# Altium schematic sheet Power.SchDoc
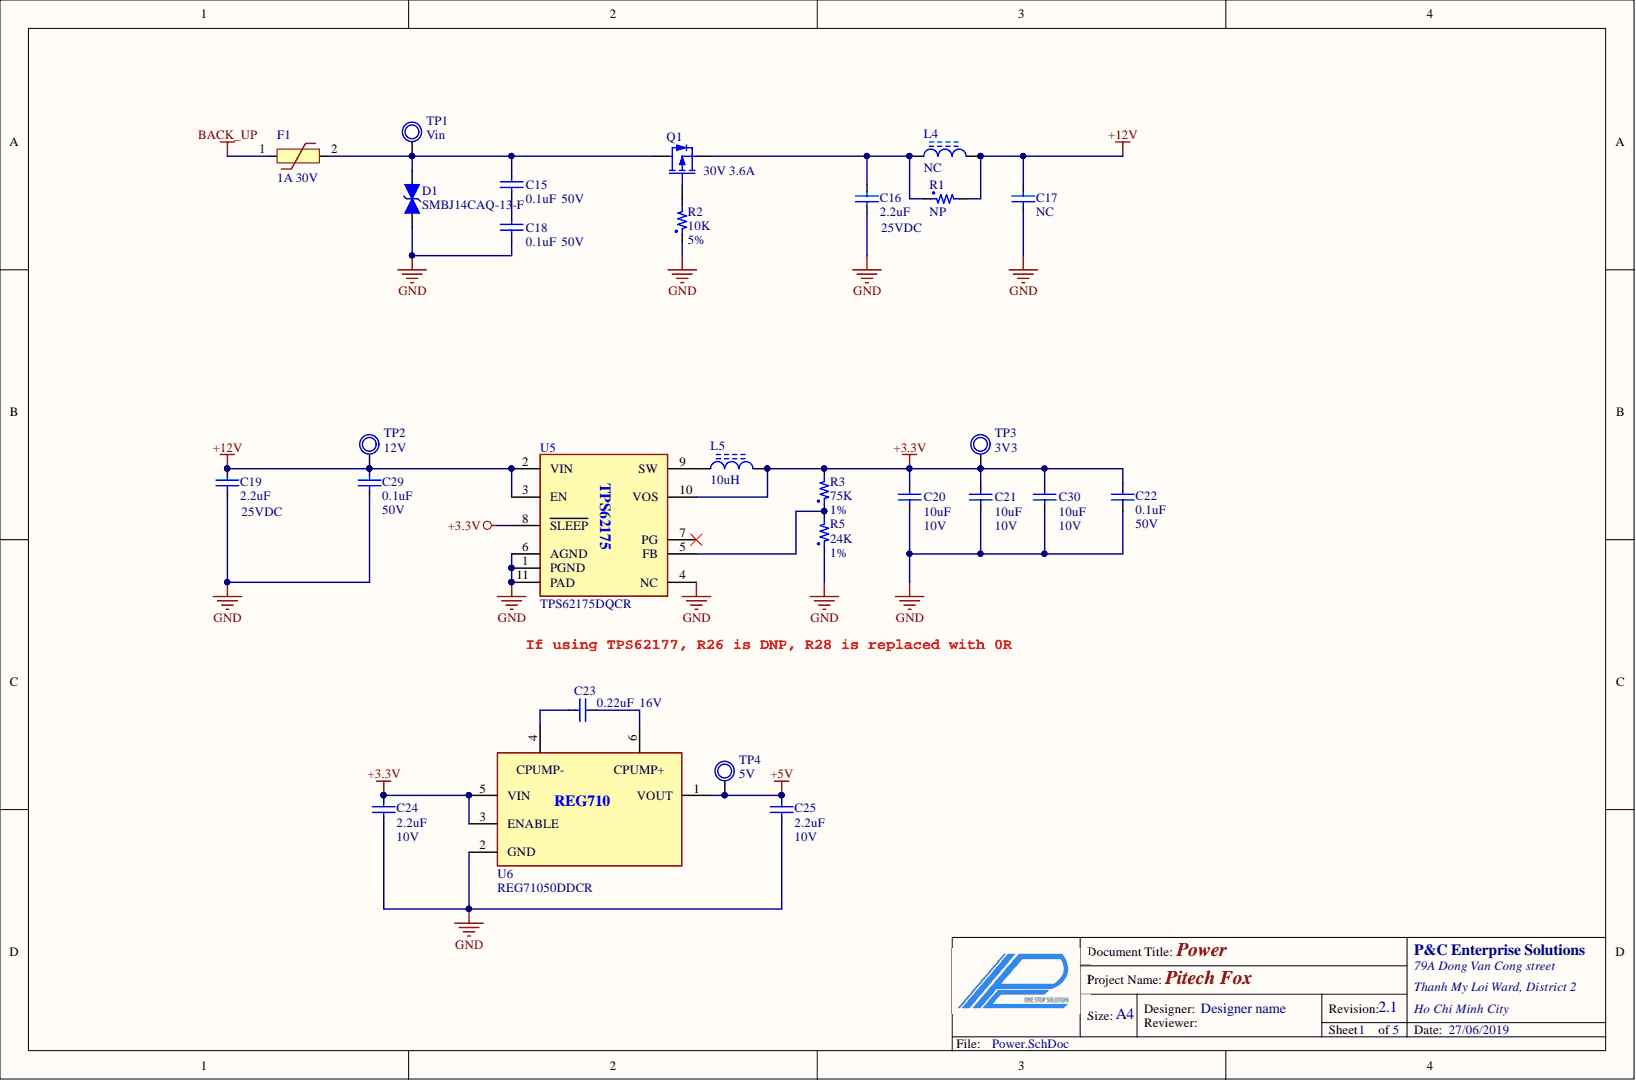
[diagram p0: Power.SchDoc]

## Parts
C15  0.1uF  [C0603 (1608 metric)]
C16  2.2uF  Voltage: 25VDC  [C0805 (2012 METRIC)]
C17  NC  [C0805 (2012 METRIC)]  (no BOM)
C18  0.1uF  [C0603 (1608 metric)]
C19  2.2uF  Voltage: 25VDC  [C0805 (2012 METRIC)]
C20  10uF  Voltage: 10V  [C0805 (2012 METRIC)]
C21  10uF  Voltage: 10V  [C0805 (2012 METRIC)]
C22  0.1uF  Voltage: 50V  [C0603 (1608 metric)]
C23  0.22uF  [C0603 (1608 metric)]
C24  2.2uF  Voltage: 10V  [C0603 (1608 metric)]
C25  2.2uF  Voltage: 10V  [C0603 (1608 metric)]
C29  0.1uF  Voltage: 50V  [C0603 (1608 metric)]
C30  10uF  Voltage: 10V  [C0805 (2012 METRIC)]
D1  SMBJ14CAQ-13-F  [SMB]
F1  1A 30V  Trip Current: 1A  [Fuse 1812 (4532 metric)]
L4  NC  [NRS4018]  (no BOM)
L5  10uH  [NRS4018]
Q1  30V 3.6A  [FET_SOT23-3]
R1  NP  [R0603 (1608 Metric)]
R2  10K  Tolerance: 5%  [R0603 (1608 Metric)]
R3  75K  Tolerance: 1%  [R0603 (1608 Metric)]
R5  24K  Tolerance: 1%  [R0603 (1608 Metric)]
TP1  Vin  [TestPoint 2mm - TH]
TP2  12V  [TestPoint 2mm - TH]
TP3  3V3  [TestPoint 2mm - TH]
TP4  5V  [TestPoint 2mm - TH]
U5  TPS62175DQCR  [DQC0010A]
U6  REG71050DDCR  [R-PDSO-G6]

## Nets
+3.3V: C20.2 C21.2 C22.2 C24.2 C30.2 L5.2 R3.2 TP3.1 U5.8(SLEEP) U5.10(VOS) U6.3(ENABLE) U6.5(VIN)
+5V: C25.2 TP4.1 U6.1(VOUT)
+12V: C17.2 C19.2 C29.2 L4.2 R1.2 TP2.1 U5.2(VIN) U5.3(EN)
BACK_UP: F1.1
GND: C16.1 C17.1 C18.1 C19.1 C20.1 C21.1 C22.1 C24.1 C25.1 C29.1 C30.1 D1.1 R2.1 R5.1 U5.1(PGND) U5.4(NC) U5.6(AGND) U5.11(PAD) U6.2(GND)
NetC15_1: C15.1 C18.2
NetC15_2: C15.2 D1.2 F1.2 Q1.3 TP1.1
NetC16_2: C16.2 L4.1 Q1.2 R1.1
NetC23_1: C23.1 U6.4
NetC23_2: C23.2 U6.6
NetL5_1: L5.1 U5.9(SW)
NetQ1_1: Q1.1 R2.2
NetR3_1: R3.1 R5.2 U5.5(FB)

Not connected: U5 7(PG)

## Library data of the parts
C15 C18 C22 C29 = UMK107B7104KAHT: Multilayer Ceramic Capacitors MLCC - SMD/SMT 0.1uF 50V X7R +/-10% 0603 AEC-Q200; Mfr: Taiyo Yuden; Tolerance: 10%; Case: 0603 (1608 metric); Component Kind: Standard; Dielectric: X7R; Library Name: CeramicCapacitor.SchLib; Mfr Part Number: UMK107B7104KAHT; Supplier 1: Mouser; Supplier Part Number 1: 963-UMK107B7104KAHT; Value: 0.1uF
  C15 C18: Voltage: 50V
C16 C19 = TMK212B7225KGHT: Multilayer Ceramic Capacitors MLCC - SMD/SMT 2.2uF 25V X7R +/-10% 0805; Mfr: Yageo; Tolerance: 10%; Case: 0805 (2012 metric); Component Kind: Standard; Dielectric: X7R; Library Name: CeramicCapacitor.SchLib; Mfr Part Number: TMK212B7225KGHT; Supplier 1: Mouser; Supplier Part Number 1: 963-TMK212B7225KGHT; Value: 2.2uF
C17 = TMK212B7225KGHT: Multilayer Ceramic Capacitors MLCC - SMD/SMT 2.2uF 25V X7R +/-10% 0805; Mfr: Yageo; Tolerance: 10%; Voltage: 25VDC; Case: 0805 (2012 metric); Dielectric: X7R; Library Name: CeramicCapacitor.SchLib; Mfr Part Number: TMK212B7225KGHT; Supplier 1: Mouser; Supplier Part Number 1: 963-TMK212B7225KGHT; Value: 2.2uF
C20 C21 C30 = LMK212ABJ106MG-T: Multilayer Ceramic Capacitors MLCC - SMD/SMT 10uF 10V X5R +/-20% 0805; Mfr: Taiyo Yuden; Tolerance: 20%; Case: 0805 (2012 metric); Component Kind: Standard; Dielectric: X5R; Library Name: CeramicCapacitor.SchLib; Mfr Part Number: LMK212ABJ106MG-T; Supplier 1: Mouser; Supplier Part Number 1: 963-LMK212ABJ106MG-T; Value: 10uF
C23 = EMK107BJ224KAHT: Multilayer Ceramic Capacitors MLCC - SMD/SMT 0.22uF 16V X7R +/-10% 0603  AEC-Q200; Mfr: Taiyo Yuden; Tolerance: 10%; Case: 0603 (1608 metric); Component Kind: Standard; Dielectric: X7R; Library Name: CeramicCapacitor.SchLib; Mfr Part Number: EMK107BJ224KAHT; Supplier 1: Mouser; Supplier Part Number 1: 963-EMK107BJ224KAHT; Value: 0.22uF; Voltage: 16V
C24 C25 = LMK107B7225KA-TR: Multilayer Ceramic Capacitors MLCC - SMD/SMT 2.2uF 10V X7R +/-10% 0603; Mfr: Taiyo Yuden; Tolerance: 10%; Case: 0603 (1608 metric); Component Kind: Standard; Dielectric: X7R; Library Name: CeramicCapacitor.SchLib; Mfr Part Number: LMK107B7225KA-TR; Supplier 1: Mouser; Supplier Part Number 1: 963-LMK107B7225KA-TR; Value: 2.2uF
D1 = SMBJ14CAQ-13-F: ESD Suppressors / TVS Diodes 16V 600W BiDir; Mfr: Diodes Incorporated; Clamping Voltage: 23.2V; Working Voltage: 14V; Component Kind: Standard; Library Name: ESD Suppressors and TVS Diodes.SchLib; Mfr Part Number: SMBJ14CAQ-13-F; Package: SMB-2; Supplier 1: Mouser; Supplier Part Number 1: 621-SMBJ14CAQ-13-F
F1 = 0ZCG0050AF2C: PTC Resettable Fuses 1A 30V, case 1812; Mfr: Bel Fuse; Hold Current: 0.5A; Maximum Voltage: 30VDC; Component Kind: Standard; Mfr Part Number: 0ZCG0050AF2C; Package: 1812 (4532 metric); Supplier 1: Mouser; Supplier Part Number 1: 530-0ZCG0050AF2C; Value: 1A 30V; Library Name: ResetableFuse.SchLib
L4 L5 = NRS4018T100MDGJ: Fixed Inductors 4018 10uH 180mOhms +/-20%Tol 1200mA; Mfr: Taiyo Yuden; Maximum DC Current: 1.2A; Maximum DC Resistance: 150mOhm; Tolerance: 20%; Library Name: Inductor.SchLib; Mfr Part Number: NRS4018T100MDGJ; Supplier 1: Mouser; Supplier Part Number 1: 963-NRS4018T100MDGJ; Value: 10uH
  L5: Component Kind: Standard
Q1 = IRLML9301TRPBF: MOSFET MOSFT P-Ch -30V -3.6A 64mOhm SOT23; Mfr: Infineon Technologies; Gate-to-Source Voltage: +/- 20V; Component Kind: Standard; Library Name: MOSFET.SchLib; Mfr Part Number: IRLML9301TRPBF; Supplier 1: Mouser; Supplier Part Number 1: 942-IRLML9301TRPBF; Value: 30V 3.6A
R1 = RC0603FR-070RL: Thick Film Resistors - SMD 0 OHM 1% Case 0603; Mfr: Yageo; Value: 0R; Case: 0603 (1608 metric); Component Kind: Standard; Library Name: ChipResistor.SchLib; Mfr Part Number: RC0603FR-070RL; Supplier 1: Mouser; Supplier Part Number 1: 603-RC0603FR-070RL; Tolerance: 1%
R2 = RC0603JR-0710KL: Thick Film Resistors - SMD 10K OHM 5% Case 0603; Mfr: Yageo; Case: 0603 (1608 metric); Component Kind: Standard; Library Name: ChipResistor.SchLib; Mfr Part Number: RC0603JR-0710KL; Supplier 1: Mouser; Supplier Part Number 1: 603-RC0603JR-0710KL; Value: 10K
R3 = RC0603FR-0775KL: Thick Film Resistors - SMD 75K OHM 1% Case 0603; Mfr: Yageo; Case: 0603 (1608 metric); Component Kind: Standard; Library Name: ChipResistor.SchLib; Mfr Part Number: RC0603FR-0775KL; Supplier 1: Mouser; Supplier Part Number 1: 603-RC0603FR-0775KL; Value: 75K
R5 = RC0603FR-0724KL: Thick Film Resistors - SMD 24K OHM 1% Case 0603; Mfr: Yageo; Case: 0603 (1608 metric); Component Kind: Standard; Library Name: ChipResistor.SchLib; Mfr Part Number: RC0603FR-0724KL; Supplier 1: Mouser; Supplier Part Number 1: 603-RC0603FR-0724KL; Value: 24K
TP1-TP4 = TP1: Test Point; Component Kind: Standard; Value: Test Point; Library Name: syhaunguyen.SchLib
U5 = TPS62175DQCR: Switching Voltage Regulators 28V 0.5A Step-Dwn Convrtr w/ SNOOZE Md; Mfr: Texas Instruments; Component Kind: Standard; Library Name: PowerManagement.SchLib; Mfr Part Number: TPS62175DQCR; Supplier 1: Mouser; Supplier Part Number 1: 595-TPS62175DQCR
U6 = REG71050DDCR: Switching Voltage Regulators 60-mA 5.0V Buck/ Boost Charge Pump; Mfr: Texas Instruments; Input Voltage: 2.7V - 5V; Output Voltage: 5V; Component Kind: Standard; Library Name: PowerManagement.SchLib; Mfr Part Number: REG71050DDCR; Package: SOT-23-Thin-6; Supplier 1: Mouser; Supplier Part Number 1: 595-REG71050DDCR
